# Altium schematic sheet ZED-F9P.SchDoc
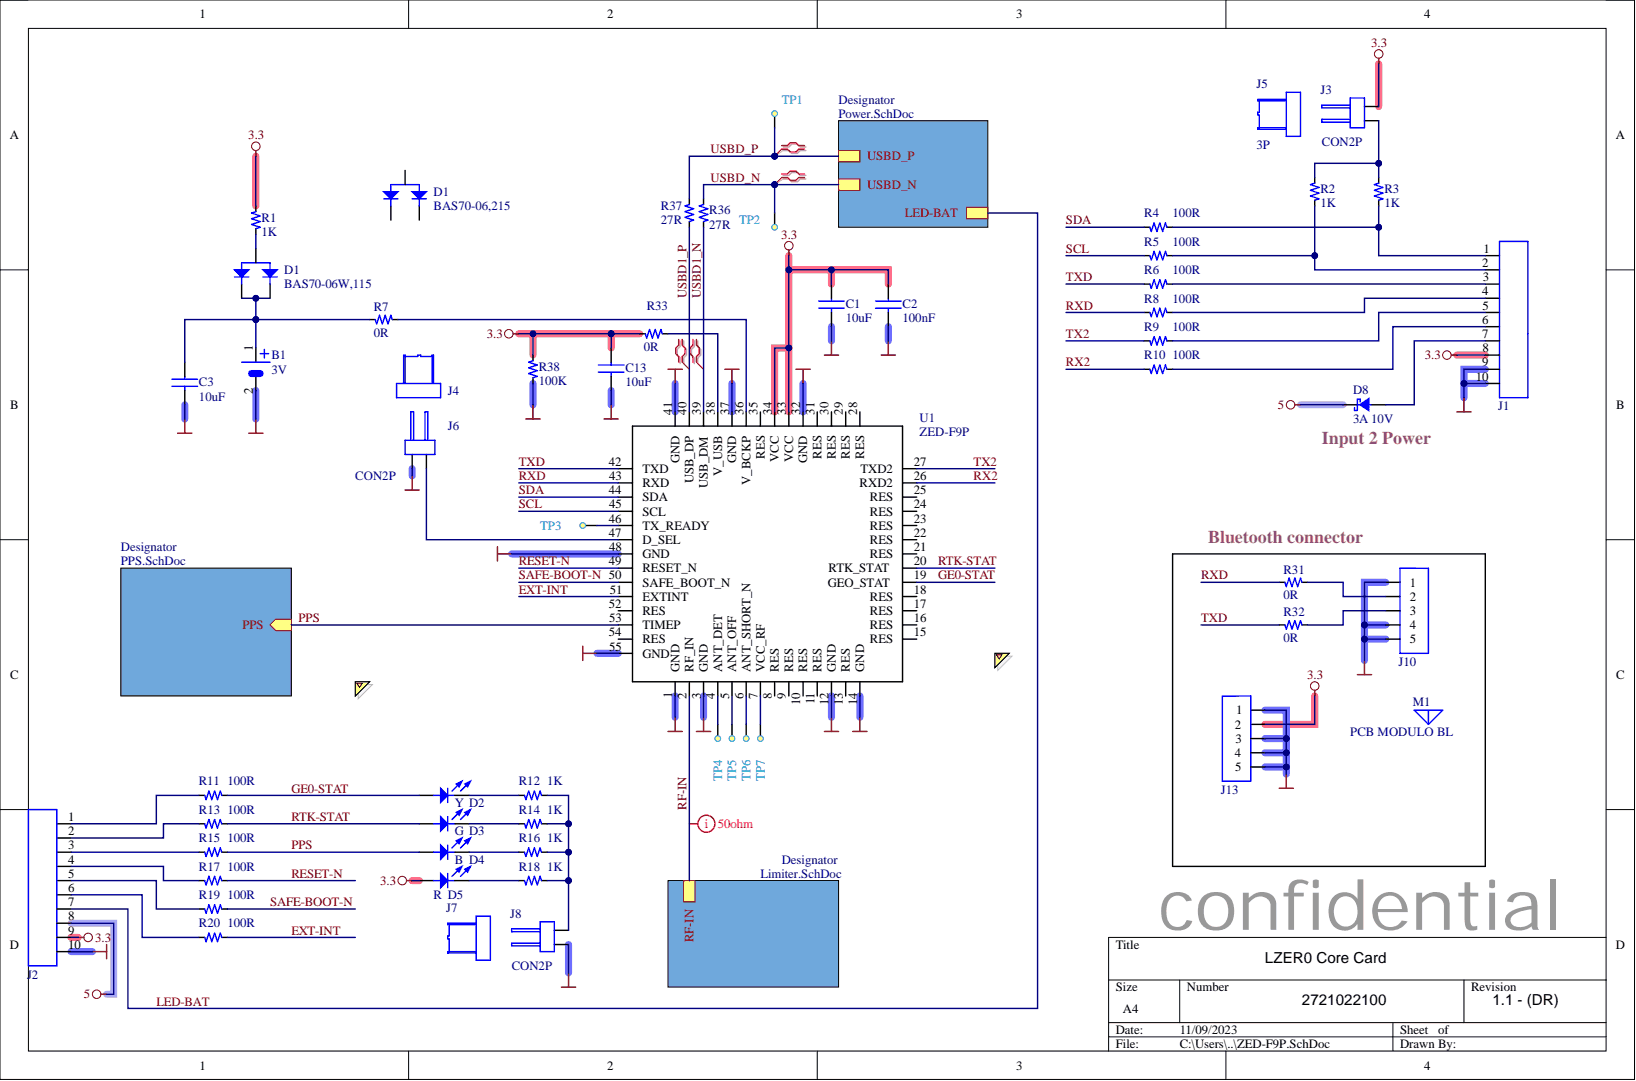
[diagram p0: ZED-F9P.SchDoc]

## Parts
B1  3V  [BAT_SMD_MS621FE-FL11E]
C1  10uF  [RC0603_1]
C2  100nF  [RC0402_1]
C3  10uF  [RC0603_1]
C13  10uF  [RC0603_1]
D1  BAS70-06,215  [SOT23BAS70-06W]
D1  BAS70-06W,115  [SOT23BAS70-06W]
D2  Y  [LED_SMD_0603_YELLOW]
D3  B  [LED_SMD_0603_BLUE]
D4  G  [LED_SMD_0603_GREEN]
D5  R  [LED_SMD_0603_RED]
D8  3A 10V  [SOD323]
J1  DF13-10P-1.25H  [CON_SMD_10PIN_DF13-10P-1.25V(21)_HIROSE]  (value not drawn)
J2  DF13-10P-1.25H  [CON_SMD_10PIN_DF13-10P-1.25V(21)_HIROSE]  (value not drawn)
J3  CON2P  [CON_TH_2PIN_2.54P_825433-2 _TE]
J4  3P  (value not drawn)
J5  3P
J6  CON2P  [CON_TH_2PIN_2.54P_825433-2 _TE]
J7  3P  (value not drawn)
J8  CON2P  [CON_TH_2PIN_2.54P_825433-2 _TE]
J10  CON5P  [CON_TH_5PIN_2.54P_61300515721_WE]  (value not drawn)
J13  CON5P  [CON_TH_5PIN_2.54P_61300515721_WE]  (value not drawn)
M1  PCB MODULO BL  [MODULO_HC06_STRIP]
R1  1K  [RC0603_1]
R2  1K  [RC0603_1]
R3  1K  [RC0603_1]
R4  100R  [RC0603_1]
R5  100R  [RC0603_1]
R6  100R  [RC0603_1]
R7  0R  [RC0402_1]
R8  100R  [RC0603_1]
R9  100R  [RC0603_1]
R10  100R  [RC0603_1]
R11  100R  [RC0603_1]
R12  1K  [RC0603_1]
R13  100R  [RC0603_1]
R14  1K  [RC0603_1]
R15  100R  [RC0603_1]
R16  1K  [RC0603_1]
R17  100R  [RC0603_1]
R18  1K  [RC0603_1]
R19  100R  [RC0603_1]
R20  100R  [RC0603_1]
R31  0R  [RC0402_1]
R32  0R  [RC0402_1]
R33  0R  [RC0402_1]
R36  27R  [RC0402_1]
R37  27R  [RC0402_1]
R38  100K  [RC0603_1]
TP1  TP  [TP_SMD_1.2W]  (no BOM)
TP2  TP  [TP_SMD_1.2W]  (no BOM)
TP3  TP  [TP_SMD_1.2W]  (no BOM)
TP4  TP  [TP_SMD_1.2W]  (no BOM)
TP5  TP  [TP_SMD_1.2W]  (no BOM)
TP6  TP  [TP_SMD_1.2W]  (no BOM)
TP7  TP  [TP_SMD_1.2W]  (no BOM)
U1  ZED-F9P  [MODULO_GPS_ZEDF9P01B_UBLOX]

## Nets
3.3: C1.1 C2.1 C13.1 D5.ANODE J1.8 J2.9 J3.2 J13.2 R1.2 R33.1 R38.1 U1.33(VCC) U1.34(VCC)
5: D8.CATHODE J2.8
GND: B1.2 C1.2 C2.2 C3.2 C13.2 J1.9 J1.10 J2.10 J6.1 J8.1 J10.1 J10.4 J10.5 J13.1 J13.3 J13.4 J13.5 R38.2 U1.1(GND) U1.3(GND) U1.12(GND) U1.14(GND) U1.32(GND) U1.37(GND) U1.41(GND) U1.48(GND) U1.55(GND)
EXT-INT: R20.2 U1.51(EXTINT)
GE0-STAT: D2.ANODE R11.2 U1.19(GEO_STAT)
LED-BAT: J2.7
PPS: D3.ANODE R15.2 U1.53(TIMEP)
RESET-N: R17.2 U1.49(RESET_N)
RF-IN: U1.2(RF_IN)
RTK-STAT: D4.ANODE R13.2 U1.20(RTK_STAT)
RX2: R10.1 U1.26(RXD2)
RXD: R8.1 R31.1 U1.43(RXD)
SAFE-BOOT-N: R19.2 U1.50(SAFE_BOOT_N)
SCL: R5.1 U1.45(SCL)
SDA: R4.1 U1.44(SDA)
TX2: R9.1 U1.27(TXD2)
TXD: R6.1 R32.1 U1.42(TXD)
USBD1_N: R36.2 U1.39(USB_DM)
USBD1_P: R37.2 U1.40(USB_DP)
USBD_N: R36.1 TP2.1
USBD_P: R37.1 TP1.1
NetB1_1: B1.1 C3.1 D1.CATHODE1 D1.CATHODE2 R7.1
NetD1_ANODE: D1.ANODE R1.1
NetD1_CATHODE1: D1.CATHODE1
NetD1_CATHODE2: D1.CATHODE2
NetD2_CATHODE: D2.CATHODE R12.1
NetD3_CATHODE: D3.CATHODE R16.1
NetD4_CATHODE: D4.CATHODE R14.1
NetD5_CATHODE: D5.CATHODE R18.1
NetD8_ANODE: D8.ANODE J1.7
NetJ1_1: J1.1 R3.1 R4.2
NetJ1_2: J1.2 R2.1 R5.2
NetJ1_3: J1.3 R6.2
NetJ1_4: J1.4 R8.2
NetJ1_5: J1.5 R9.2
NetJ1_6: J1.6 R10.2
NetJ2_1: J2.1 R11.1
NetJ2_2: J2.2 R13.1
NetJ2_3: J2.3 R15.1
NetJ2_4: J2.4 R17.1
NetJ2_5: J2.5 R19.1
NetJ2_6: J2.6 R20.1
NetJ3_1: J3.1 R2.2 R3.2
NetJ6_2: J6.2 U1.47(D_SEL)
NetJ8_2: J8.2 R12.2 R14.2 R16.2 R18.2
NetJ10_2: J10.2 R31.2
NetJ10_3: J10.3 R32.2
NetR7_2: R7.2 U1.36(V_BCKP)
NetR33_2: R33.2 U1.38(V_USB)
NetTP3_1: TP3.1 U1.46(TX_READY)
NetTP4_1: TP4.1 U1.4(ANT_DET)
NetTP5_1: TP5.1 U1.5(ANT_OFF)
NetTP6_1: TP6.1 U1.6(ANT_SHORT_N)
NetTP7_1: TP7.1 U1.7(VCC_RF)
NetU1_8: U1.8(RES)
NetU1_9: U1.9(RES)
NetU1_10: U1.10(RES)
NetU1_11: U1.11(RES)
NetU1_13: U1.13(RES)
NetU1_15: U1.15(RES)
NetU1_16: U1.16(RES)
NetU1_17: U1.17(RES)
NetU1_18: U1.18(RES)
NetU1_21: U1.21(RES)
NetU1_22: U1.22(RES)
NetU1_23: U1.23(RES)
NetU1_24: U1.24(RES)
NetU1_25: U1.25(RES)
NetU1_28: U1.28(RES)
NetU1_29: U1.29(RES)
NetU1_30: U1.30(RES)
NetU1_31: U1.31(RES)
NetU1_35: U1.35(RES)
NetU1_52: U1.52(RES)
NetU1_54: U1.54(RES)

## Library data of the parts
B1 = BATTERY_LITIO_RTC_MS621FE-FL11E: Recharge Battery/Tab RTC/SRAM Backup; Manufacturer: SII; Order c: 628-MS621FE-FL11E; Component Kind: Standard; Library Name: mylibA.SchLib; Manufacturer n: MS621FE-FL11E
C1 C3 C13 = GRM188R61A106ME69D: CAP, MLCC, X5R, 10UF, 10V, X5R, 0603; Manufacturer: MURATA; Order c: 81-GRM188R61A106ME9D; upBCE: 0.03; Case: 0603; Component Kind: Standard; Library Name: mylibA.SchLib; Manufacturer n: GRM188R61A106ME69D
C2 = GRM155R71C104KA88D: CAP, CERAMICA, 100NF, 16V, X7R, 0402; Manufacturer: MURATA; Order c: 8819742; upBCE: 0.01; Value: 0.1UF; Case: 0402; Component Kind: Standard; Library Name: mylibA.SchLib; Manufacturer n: GRM155R71C104KA88D
D1 = BAS70-06W: DIODO DOPPIO SCHOTTKY, 70V, 0.15A, SOT23; Manufacturer: NXP; Order c: 771-BAS70-06-T/R; Case: SOT23; Component Kind: Standard; Library Name: mylibA.SchLib; Manufacturer n: BAS70-06,215; Value: BAS70-06,215
D1 = BAS70-06W: DIODO DOPPIO SCHOTTKY, 70V, 0.15A, SOT323(SC70); Manufacturer: NXP; Order c: 771-BAS70-06W-T/R; Case: SOT323; Component Kind: Standard; Library Name: mylibA.SchLib; Manufacturer n: BAS70-06W,115; Value: BAS70-06W,115
D2 = LED_YELLOW_0603: LED, 0603, YELLOW, 150MCD, 590NM; Manufacturer: KINGBRIGHT; Manufacturer n: APT1608SYCK; Order c: 604-APT1608SYCK; upBCE: 0.047; Case: SMD; Component Kind: Standard; Library Name: mylibA.SchLib; Value: YELLOW
D3 = LED_BLUE_0603: LED, 0603, BLUE, 180MCD, 470NM; Manufacturer: KINGBRIGHT; Manufacturer n: APT1608VBC/D; Order c: 604-APT1608VBC/D; upBCE: 0.141; Case: SMD; Component Kind: Standard; Library Name: mylibA.SchLib; Value: BLUE
D4 = LED_ GREEN_0603_WURTH: LED, 0603, GREEN, 15MCD, 675NM; Manufacturer: Wurth; Manufacturer n: 150060VS55040; upBCE: 0.1; Case: SMD; Component Kind: Standard; Library Name: mylibA.SchLib; Value: GREEN
D5 = LED_ RED_0603_1: LED, 0603, RED, 15MCD, 625NM; Manufacturer: KINGBRIGHT; Manufacturer n: KPT-1608EC; Order c: 2099221; Case: 0603; Component Kind: Standard; Library Name: mylibA.SchLib; Value: RED
D8 = BAT60JFILM: DIODO, SCHOTTKY, 3A, 10V, SMD; Manufacturer: ST; Order c: 1467544; Case: SOD-323; Component Kind: Standard; Library Name: mylibA.SchLib; Manufacturer n: BAT60JFILM; Value: 3A 10V
J1 J2 = HEADER_1.25_HIROSE_10VIE_SMD: CONNECTOR 10P SNG ROW PIN HDR R/A SMT; Manufacturer: HIROSE; Manufacturer n: DF13-10P-1.25V(76); Order c: 798-DF13-10P-1.25V76; upBCE: 0.60; Component Kind: Standard; Library Name: mylibA.SchLib
J3 J6 J8 = HEADER_2.54_PINSTRIP_BIPHASE: HEADER, P2.54, H6.7MM, BIFASE; Manufacturer: TE; Manufacturer n: 825433-2; Order c: 3417645; Component Kind: Standard; Library Name: mylibA.SchLib
J4 J5 J7 = HEADER_2.54_PINSTRIP_2VIE_1RIGA_JUMPER: JUMPER P2.54, H13.5MM, FEMMINA 2 VIE; Manufacturer: MULTICOMP; Manufacturer n: SPC20479.; Order c: 2396301; upBCE: 0.075; Component Kind: Standard; Library Name: mylibA.SchLib
J10 J13 = HEADER_PCB_5P_2.54_SMD_WURTH: MORSETTIERA, PCB, 2.5MM, 5 VIE, 12 AMPER; Manufacturer: WURTH; Manufacturer n: 61300515721; Order c: 2827892; upBCE: 0.753; Component Kind: Standard; Library Name: mylibA.SchLib
M1 = Antenna: Generic Antenna; Component Kind: Standard; LatestRevisionDate: 17-Jul-2002; LatestRevisionNote: Re-released for DXP Platform.; Library Name: Miscellaneous Devices.IntLib; PackageReference: PIN1; Published: 24-Mar-1999; Publisher: Altium Limited
R1-R3 R12 R14 R16 R18 = CRCW06031K00FKEA: RESISTORE, 1K, 0.1W, 1%, 0603, SMD; Manufacturer: Vishay Dralogic; Order c: 1469740; upBCE: 0.00066; Case: 0603; Component Kind: Standard; Library Name: mylibA.SchLib; Manufacturer n: CRCW06031K00FKEA; Value: 1K
R4-R6 R8-R11 R13 R15 R17 R19 R20 = CRCW0603100RFKEA: RESISTORE, 100R, 0.1W, 1%, 0603, SMD; Manufacturer: Vishay Dralogic; Order c: 1469752; upBCE: 0.00066; Case: 0603; Component Kind: Standard; Library Name: mylibA.SchLib; Manufacturer n: CRCW0603100RFKEA; Value: 100R
R7 R31-R33 = CRCW04020000Z0ED: RESISTORE, 0R, 0.1W, 1%, 0402, SMD; Manufacturer: Vishay Dralogic; Order c: 1469661; upBCE: 0.0006; Case: 0402; Component Kind: Standard; Library Name: mylibA.SchLib; Manufacturer n: CRCW04020000Z0ED; Value: 0R
R36 R37 = CRCW040227R0JNED: RESISTORE, 27R, 0.063W, 5%, 0402, SMD; Manufacturer: Vishay Dralogic; Order c: 3546346; Case: 0402; Component Kind: Standard; Library Name: mylibA.SchLib; Manufacturer n: CRCW040227R0JNED; Value: 27R
R38 = CRCW0603100KFKEA: RESISTORE, 100K, 0.1W, 1%, 0603, SMD; Manufacturer: Vishay Dralogic; Order c: 1469649; upBCE: 0.00066; Value: 100R; Case: 0603; Component Kind: Standard; Library Name: mylibA.SchLib; Manufacturer n: CRCW0603100KFKEA
TP1-TP7 = TP2(small): SMD, TEST POINT; Case: SMD; Library Name: mylibA.SchLib
U1 = ZED-F9P: u-blox F9 high precision GNSS module; upBCE: 169; Case: SMD; Component Kind: Standard; Library Name: mylibA.SchLib; Manufacturer: u-blox; Manufacturer c: ZED-F9P
